# Altium schematic sheet POWERSYSTEM.SchDoc
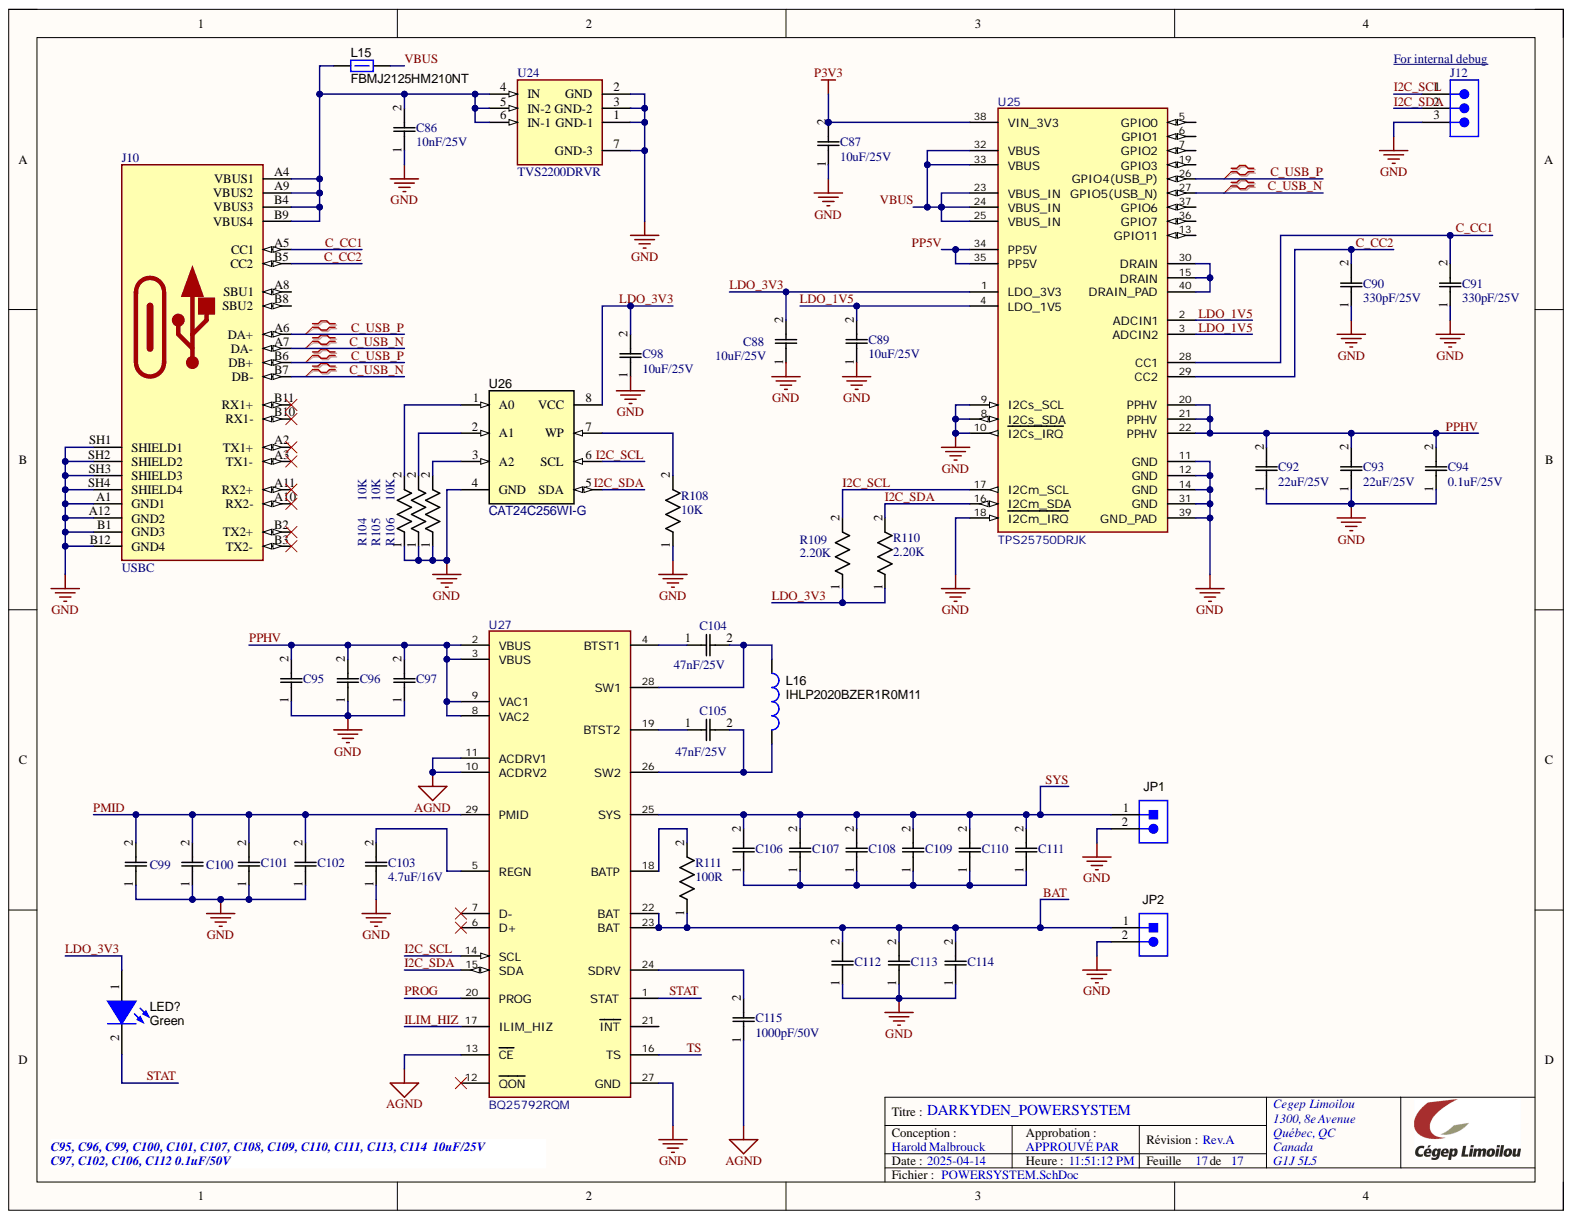
[diagram p0: POWERSYSTEM.SchDoc]

## Parts
C86  10nF/25V  [CAP_CC0805_YAG]
C87  10uF/25V  [CAP_CC0805_YAG]
C88  10uF/25V  [CAP_CC0805_YAG]
C89  10uF/25V  [CAP_CC0805_YAG]
C90  330pF/25V  [CAP_CC0805_YAG]
C91  330pF/25V  [CAP_CC0805_YAG]
C92  22uF/25V  [CAP_CC0805_YAG]
C93  22uF/25V  [CAP_CC0805_YAG]
C94  0.1uF/25V  [CAP_CC0805_YAG]
C95  10uF/25V  [CAP_CC0805_YAG]  (value not drawn)
C96  10uF/25V  [CAP_CC0805_YAG]  (value not drawn)
C97  0.1uF/50V  [CAP_CC0805_YAG]  (value not drawn)
C98  10uF/25V  [CAP_CC0805_YAG]
C99  10uF/25V  [CAP_CC0805_YAG]  (value not drawn)
C100  10uF/25V  [CAP_CC0805_YAG]  (value not drawn)
C101  10uF/25V  [CAP_CC0805_YAG]  (value not drawn)
C102  0.1uF/50V  [CAP_CC0805_YAG]  (value not drawn)
C103  4.7uF/16V  [CAP_CC0805_YAG]
C104  47nF/25V  [CAP_CC0805_YAG]
C105  47nF/25V  [CAP_CC0805_YAG]
C106  0.1uF/50V  [CAP_CC0805_YAG]  (value not drawn)
C107  10uF/25V  [CAP_CC0805_YAG]  (value not drawn)
C108  10uF/25V  [CAP_CC0805_YAG]  (value not drawn)
C109  10uF/25V  [CAP_CC0805_YAG]  (value not drawn)
C110  10uF/25V  [CAP_CC0805_YAG]  (value not drawn)
C111  10uF/25V  [CAP_CC0805_YAG]  (value not drawn)
C112  0.1uF/50V  [CAP_CC0805_YAG]  (value not drawn)
C113  10uF/25V  [CAP_CC0805_YAG]  (value not drawn)
C114  10uF/25V  [CAP_CC0805_YAG]  (value not drawn)
C115  1000pF/50V  [CAP_CC0805_YAG]
J10  USBC  [MOLEX_2012670005]
J12  61300311121  [61300311121]  (value not drawn)
JP1  Header_2x1  [CONN_PEC02SAAN]  (value not drawn)
JP2  Header_2x1  [CONN_PEC02SAAN]  (value not drawn)
L15  FBMJ2125HM210NT  [0805_HV]
L16  IHLP2020BZER1R0M11  [IHLP-2020BZ]
LED?  value Green  [LED_LTST-C190]  (hidden comment: LTST-C190GKT)
R104  10K  [RES_0816_SUS]
R105  10K  [RES_0816_SUS]
R106  10K  [RES_0816_SUS]
R108  10K  [RES_0816_SUS]
R109  2.20K  [RES_0816_SUS]
R110  2.20K  [RES_0816_SUS]
R111  100R  [RES_0816_SUS]
U24  TVS2200DRVR  [SON65P200X200X80-7N]
U25  TPS25750DRJK  [RJK0038B-C01-MFG]
U26  CAT24C256WI-G  [SOIC-8]
U27  BQ25792RQM  [RQM0029A-MFG]

## Nets
AGND: C115.1 U27.10(ACDRV2) U27.11(ACDRV1) U27.13(CE)
GND: C86.1 C87.1 C88.1 C89.1 C90.1 C91.1 C92.1 C93.1 C94.1 C95.1 C96.1 C97.1 C98.1 C99.1 C100.1 C101.1 C102.1 C103.1 C112.1 C113.1 C114.1 J10.A1(GND1) J10.A12(GND2) J10.B1(GND3) J10.B12(GND4) J10.SH1(SHIELD1) J10.SH2(SHIELD2) J10.SH3(SHIELD3) J10.SH4(SHIELD4) J12.3 JP1.2 JP2.2 R104.1 R105.1 R106.1 R108.1 U24.1(GND-1) U24.2(GND) U24.3(GND-2) U24.7(GND-3) U25.8(I2Cs_SDA) U25.9(I2Cs_SCL) U25.10(I2Cs_IRQ) U25.11(GND) U25.12(GND) U25.14(GND) U25.18(I2Cm_IRQ) U25.31(GND) U25.39(GND_PAD) U26.4(GND) U27.27(GND)
P3V3: C87.2 U25.38(VIN_3V3)
BAT: C112.2 C113.2 C114.2 JP2.1 R111.1 U27.22(BAT) U27.23(BAT)
C_CC1: C91.2 J10.A5(CC1) U25.28(CC1)
C_CC2: C90.2 J10.B5(CC2) U25.29(CC2)
C_USB_N: J10.A7(DA-) J10.B7(DB-) U25.27(GPIO5(USB_N))
C_USB_P: J10.A6(DA+) J10.B6(DB+) U25.26(GPIO4(USB_P))
I2C_SCL: J12.1 R109.2 U25.17(I2Cm_SCL) U26.6(SCL) U27.14(SCL)
I2C_SDA: J12.2 R110.2 U25.16(I2Cm_SDA) U26.5(SDA) U27.15(SDA)
ILIM_HIZ: U27.17(ILIM_HIZ)
LDO_1V5: C89.2 U25.2(ADCIN1) U25.3(ADCIN2) U25.4(LDO_1V5)
LDO_3V3: C88.2 C98.2 LED?.1 R109.1 R110.1 U25.1(LDO_3V3) U26.8(VCC)
PMID: C99.2 C100.2 C101.2 C102.2 U27.29(PMID)
PP5V: U25.34(PP5V) U25.35(PP5V)
PPHV: C92.2 C93.2 C94.2 C95.2 C96.2 C97.2 U25.20(PPHV) U25.21(PPHV) U25.22(PPHV) U27.2(VBUS) U27.3(VBUS) U27.8(VAC2) U27.9(VAC1)
PROG: U27.20(PROG)
STAT: LED?.2 U27.1(STAT)
SYS: C106.2 C107.2 C108.2 C109.2 C110.2 C111.2 JP1.1 U27.25(SYS)
TS: U27.16(TS)
VBUS: L15.2 U25.23(VBUS_IN) U25.24(VBUS_IN) U25.25(VBUS_IN) U25.32(VBUS) U25.33(VBUS)
NetC86_2: C86.2 J10.A4(VBUS1) J10.A9(VBUS2) J10.B4(VBUS3) J10.B9(VBUS4) L15.1 U24.4(IN) U24.5(IN-2) U24.6(IN-1)
NetC103_2: C103.2 U27.5(REGN)
NetC104_1: C104.1 U27.4(BTST1)
NetC104_2: C104.2 L16.1 U27.28(SW1)
NetC105_1: C105.1 U27.19(BTST2)
NetC105_2: C105.2 L16.2 U27.26(SW2)
NetC106_1: C106.1 C107.1 C108.1 C109.1 C110.1 C111.1
NetC115_2: C115.2 U27.24(SDRV)
NetR104_2: R104.2 U26.1(A0)
NetR105_2: R105.2 U26.2(A1)
NetR106_2: R106.2 U26.3(A2)
NetR108_2: R108.2 U26.7(WP)
NetR111_2: R111.2 U27.18(BATP)
NetU25_15: U25.15(DRAIN) U25.30(DRAIN) U25.40(DRAIN_PAD)

Not connected: J10 A2(TX1+) A3(TX1-) A8(SBU1) A10(RX2-) A11(RX2+) B2(TX2+) B3(TX2-) B8(SBU2) B10(RX1-) B11(RX1+); U25 5(GPIO0) 6(GPIO1) 7(GPIO2) 13(GPIO11) 19(GPIO3) 36(GPIO7) 37(GPIO6); U27 6(D+) 7(D-) 12(QON) 21(INT)

## Library data of the parts
C86-C115 = Capacitor_0805: No Description Available; Mfr_Name: Yageo; Component Kind: Standard; Library Name: Capacitor_0805.SchLib
J10 = USBC: USB-C (USB TYPE-C) USB 3.2 Gen 2 (USB 3.1 Gen 2, Superspeed + (USB 3.1)) Receptacle Connector 24 Position Surface Mount, Right Angle; Through Hole; MF: Molex; MP: 2012670005; Check_prices: https://www.snapeda.com/parts/2012670005/Molex/view-part/?ref=eda; Component Kind: Standard; Library Name: USBC.SchLib; Package: None; SnapEDA_Link: https://www.snapeda.com/parts/2012670005/Molex/view-part/?ref=snap
J12 = 61300311121: WR-PHD Pin Header, THT, Vertical, pitch 2.54mm, 1 Row, 3P; Manufacturer: Wurth Elektronik; Contact Resistance: 20mOhm; Rated Current: 3A; Withstanding Voltage: 500V; Working Voltage: 250V; Category: Board-to-Board Connectors; Component Kind: Standard; ComponentLink1Description: Website Link; ComponentLink1URL: https://www.we-online.com/redexpert/article/61300311121?ad; ComponentLink2Description: Datasheet Link; ComponentLink2URL: https://www.we-online.com/redexpert/spec/61300311121?ad; Gender: Pin Header; Library Name: Header.IntLib; Manufacturer Part Number: 61300311121; Match Code: WR-PHD; Mount: Through Hole; Number of Pins: 3; Number of Rows: 1; Operating Temperature Max: 105°C; Operating Temperature Min: -40°C; Orientation: Vertical; Packaging: Bag; Pitch: 2.54mm
JP1 JP2 = Header_2x1: Header, 100mil, 2x1, Tin, TH; Manufacturer: Sullins Connector Solutions; PartNumber: PEC02SAAN; Cert_UL: Y; CNHeader: TRUE; CT: FALSE; Current_A: 3A; Gender: Male; Green_Lifecycle: Not Set; Green_REACh: Incomplete; Pitch_mm: 2.54; Row_Count: 1; Shrouded: N; Voltage_V: 1.8kV; ClassName: Header; Color: Black; Component Kind: Standard; ComponentLink1Description: Datasheet URL; ComponentLink1URL: http://www.sullinscorp.com/catalogs/77_PAGE108-109_.100_MALE_HDR.pdf; CompType: Header; ContactFinish: Tin; Green_EU RoHS: Incomplete; Green_EU RoHS-2: Incomplete; LatestRevisionDate: 26/05/2017; MountType: TH; Orientation: Vertical; PackageReference: Header, 2 PIN, 100mil, Tin; PinCount: 2; RoHS: Y; Supplier 1: Digi-Key; Supplier Part Number 1: S1012E-02-ND; Library Name: texas_instrument_power.IntLib; Publisher: Texas Instruments
L15 = CMP-0003375-1: Ferrite Bead, 21 ohm @ 100 MHz, 6 A, 0805; Manufacturer: Taiyo Yuden; DCR_ohm: 4m; Imax_A: 6A; SizeArea_mm2: 2.5; SizeAreaWB_mm2: 6.75; SizeX_mm: 2; SizeY_mm: 1.25; SizeZ_mm: 1.45; Value: 21 ohm; Value1: 0.004 ohm; ZTyp@100MHz_Ohm: 21; Component Kind: Standard; ComponentLink1Description: Datasheet link; ComponentLink1URL: http://www.yuden.co.jp/us/product/pdf/efbm_e.pdf; CompType: Ferrite Bead; LatestRevisionDate: 2017-03-09; Library Name: Altium Vault - TI; MountType: SMT; PackageReference: 0805; PartNumber: FBMJ2125HM210NT; Published: 2014-04-30; RoHS: Y; Supplier 1: Digi-Key; Supplier Part Number 1: 587-1765-1-ND; Value2: 6A; ValueDisplayed: 21 ohm; Publisher: Texas Instruments
L16 = IHLP2020BZER1R0M11: Inductor, Shielded Drum Core, Powdered Iron, 1 uH, 7 A, 0.0181 ohm, SMD; Manufacturer: Vishay-Dale; AEC Category: Q200; DCR_ohm: 0.0181; Green_Lifecycle: Active; Green_REACh: Passed; Imax_A: 7A; Ind_H: 1µH; Irms: 7.5A @ 40C rise; Isat: 7A @ 20% inductance drop; SizeArea_mm2: 28.4382; SizeAreaWB_mm2: 53.7782; SizeX_mm: 5.49; SizeY_mm: 5.18; SizeZ_mm: 2; Value: 1uH; AEC Qualified: ?; Component Kind: Standard; ComponentLink1Description: Datasheet link; ComponentLink1URL: http://www.vishay.com/docs/34261/lp20bz11.pdf; CompType: Inductor; Green_EU RoHS: Passed; Green_EU RoHS-2: Passed; LatestRevisionDate: 2017-06-02; Material: Powdered Iron; MountType: SMT; PackageReference: 5.49x2x5.18mm; PartNumber: IHLP2020BZER1R0M11; Published: 2008-06-26; RoHS: Y; Supplier 1: Digi-Key; Supplier Part Number 1: 541-1218-1-ND; Technology: Shielded Drum Core; Value1: 0.0181 ohm; Value2: 7A; Library Name: texas_instrument_power.IntLib; Publisher: Texas Instruments
LED? = LED_Gr_SMD: LED, Green, SMD; Manufacturer: Lite-On; Io_A: 20mA; Power_TotalModule: 100mW; Value1: 0.1W; Value2: 2.1V; Color: green; Component Kind: Standard; ComponentLink1Description: Datasheet link; ComponentLink1URL: https://sps06.itg.ti.com/sites/KMS/crossdivteams/altium/docs/ComponentDatasheets/LTST-C190GKT.pdf; CompType: LED; MountType: SMT; PackageReference: 1.6x0.8x0.8mm; PartNumber: LTST-C190GKT; RoHS: Y; Type: ?; Vf_V: 2.1V; Library Name: texas_instrument_power.IntLib
R104-R106 R108-R111 = RG1608P-104-B-T5: No Description Available; Mfr_Name: Susumu; Component Kind: Standard; Library Name: RES_SMD_0603.SchLib
U24 = TVS2200DRVR: 22V flat-clamp surge protection device; MF: Texas Instruments; Check_prices: https://www.snapeda.com/parts/TVS2200DRVR/Texas+Instruments/view-part/?ref=eda; Component Kind: Standard; Library Name: TVS2200.SchLib; MP: TVS2200DRVR; Package: WSON-6 Texas Instruments; SnapEDA_Link: https://www.snapeda.com/parts/TVS2200DRVR/Texas+Instruments/view-part/?ref=snap
U25 = TPS25750DRJK: USB Type-C and USB PD Controller with Integrated Power Switches Optimized for Power Applications; Manufacturer: Texas Instruments; AEC Category: Q200; AEC Qualified: No; CompType: IC; Max Supply Voltage: 22V; Min Supply Voltage: 3V; Number Of Ports: 1; SizeZ_mm: 0.8mm; Standoff Height: 0mm; TaMax_C: +105°C; TaMin_C: -40°C; TJmax_C: +175°C; Usb Standard: USB 3.0; Component Kind: Standard; ComponentLink1Description: Datasheet Link; ComponentLink1URL: http://www.ti.com/lit/ds/symlink/GPN.pdf; ComponentLink2Description: Product Folder; ComponentLink2URL: http://www.ti.com/product/GPN; ComponentLink3Description: Online Datasheet; ComponentLink3URL: http://www.ti.com/product/GPN/datasheet; Datasheet Path: datasheets/IC-TPS25750DRJK.pdf; helpURL: https://upverter.com/upn/revision/5be88369cac593dc/; Library Name: PD_BQ_Ref_Design.SCHLIB; Operating Temperature Range: -40°C to +125°C; PackageReference: VQFN32; Part Number: TPS25750DRJK; RoHS: UNKNOWN
U26 = CAT24C256WI-G: 256 kb I2C CMOS Serial EEPROM, SOIC-8; Manufacturer: ON Semiconductor; SizeArea_mm2: 29.351; SizeAreaWB_mm2: 55.131; SizeX_mm: 5.99; SizeY_mm: 4.9; SizeZ_mm: 1.75; Component Kind: Standard; ComponentLink1Description: Datasheet URL; ComponentLink1URL: http://www.onsemi.com/pub_link/Collateral/CAT24C256-D.PDF; LatestRevisionDate: 09/03/2017; Library Name: PD_BQ_Ref_Design.SCHLIB; MountType: SMT; PackageReference: SOIC-8; PartNumber: CAT24C256WI-G; RoHS: Y; Supplier 1: Digi-Key; Supplier 2: Newark; Supplier 3: Mouser; Supplier Part Number 1: CAT24C256WI-G-ND; Supplier Part Number 2: 06R0552; Supplier Part Number 3: 698-CAT24C256WI-G; Publisher: Texas Instruments
U27 = BQ2579x: BQ2579x HotRod; Manufacturer: Texas Instruments; SizeZ_mm: 1mm; Standoff Height: 0mm; Component Kind: Standard; Datasheet Path: datasheets/IC-BQ25792RQM.pdf; helpURL: https://upverter.com/upn/revision/94d0648e55e6c5c0/; Library Name: texas_instrument_power.IntLib; Operating Temperature Range: to; PackageReference: VQFN-HR29; Part Number: BQ25792RQM; RoHS: UNKNOWN
